# Altium schematic sheet 02_Simple_ARM.SchDoc(02_Simple_ARM)
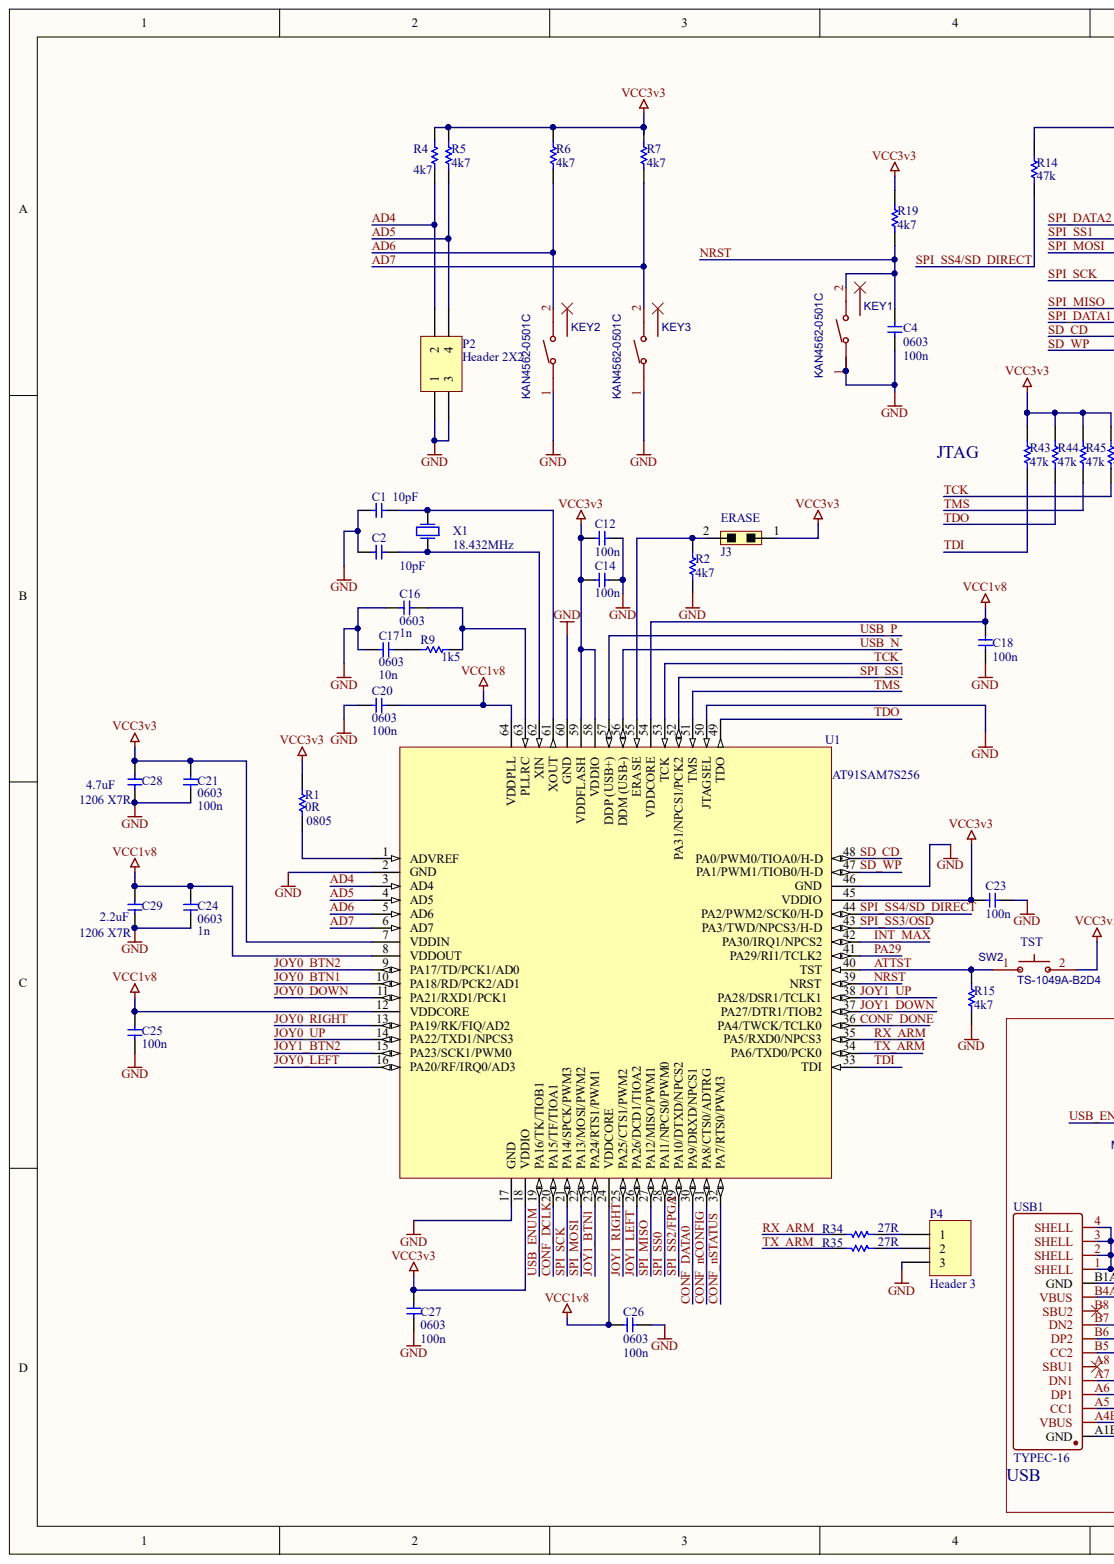
[diagram p0: 02_Simple_ARM.SchDoc, left]
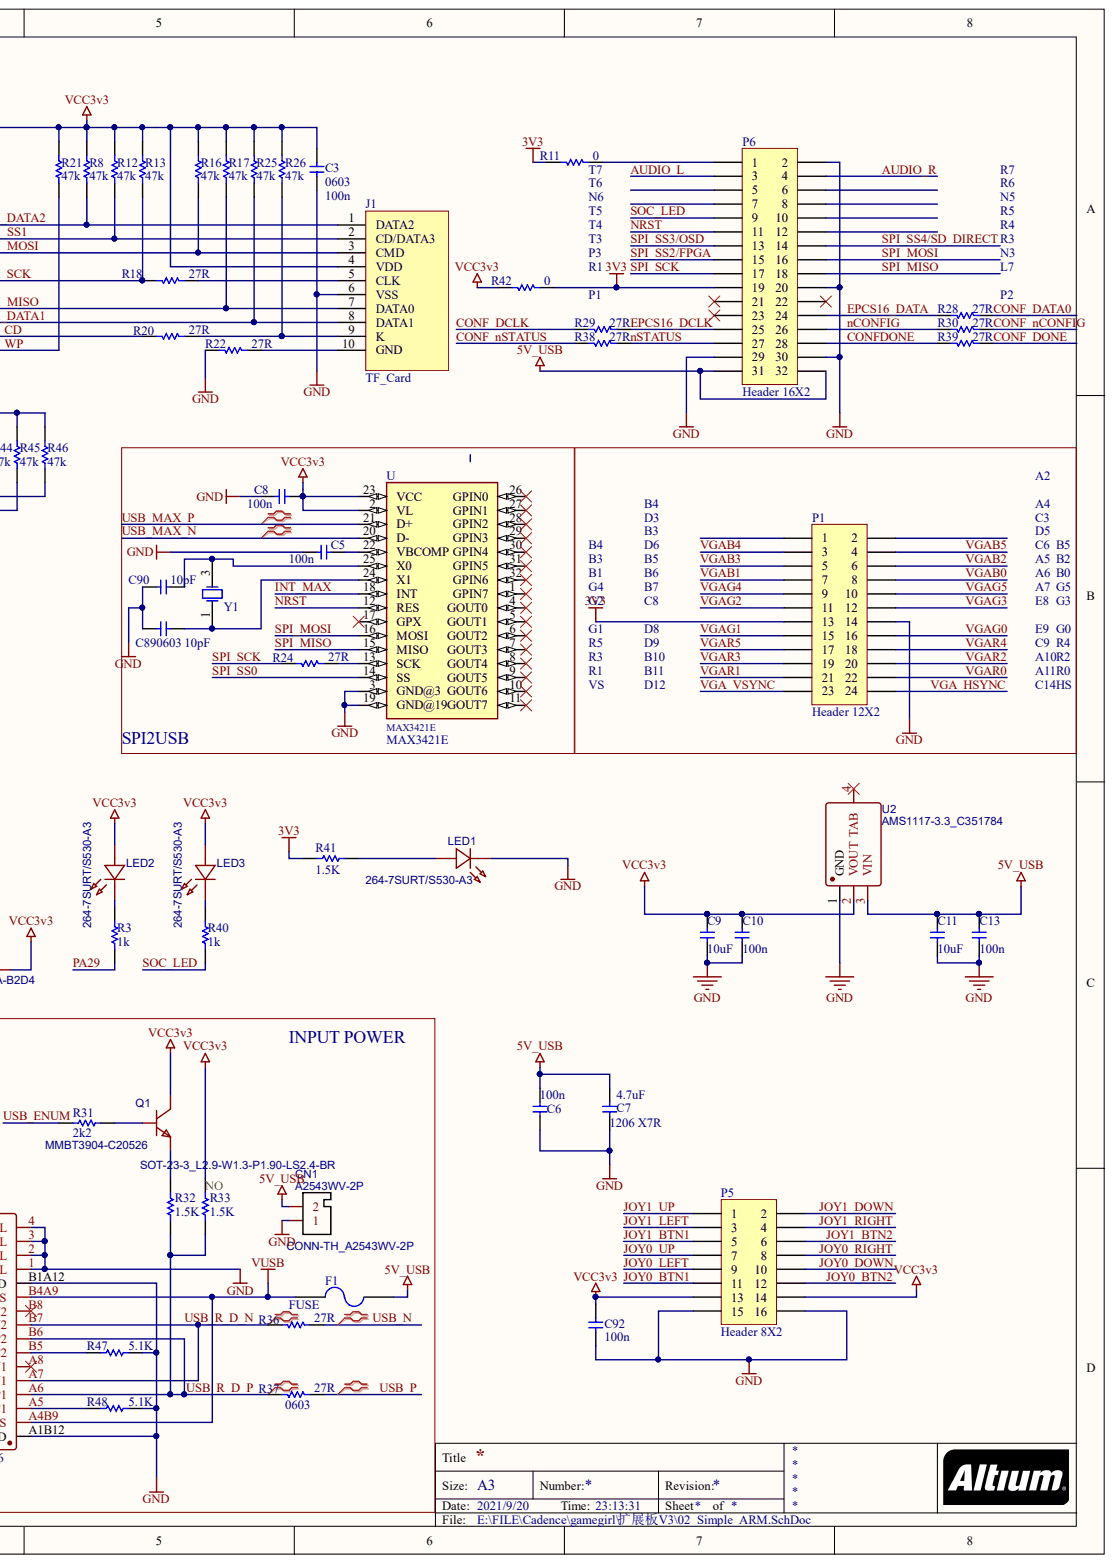
[diagram p1: 02_Simple_ARM.SchDoc, right]

## Parts
C1  value 10pF  [0603]  (hidden comment: 0603)
C2  value 10pF  [0603]  (hidden comment: 0603)
C3  0603  value 100n  [0603]
C4  0603  value 100n  [0603]
C5  value 100n  [0603]  (hidden comment: 0603)
C6  value 100n  [0603]  (hidden comment: 0603)
C7  1206 X7R  value 4.7uF  [0805]
C8  value 100n  [0603]  (hidden comment: 0603)
C9  value 10uF  [0603]  (hidden comment: 0603)
C10  value 100n  [0603]  (hidden comment: 0603)
C11  value 10uF  [0603]  (hidden comment: 0603)
C12  value 100n  [0603]  (hidden comment: 0603)
C13  value 100n  [0603]  (hidden comment: 0603)
C14  value 100n  [0603]  (hidden comment: 0603)
C16  0603  value 1n  [0603]
C17  0603  value 10n  [0603]
C18  value 100n  [0603]  (hidden comment: 0603)
C20  0603  value 100n  [0603]
C21  0603  value 100n  [0603]
C23  value 100n  [0603]  (hidden comment: 0603)
C24  0603  value 1n  [0603]
C25  value 100n  [0603]  (hidden comment: 0603)
C26  0603  value 100n  [0603]
C27  0603  value 100n  [0603]
C28  1206 X7R  value 4.7uF  [0805]
C29  1206 X7R  value 2.2uF  [0805]
C89  0603  value 10pF  [0603]
C90  value 10pF  [0603]  (hidden comment: 0603)
C92  value 100n  [0603]  (hidden comment: 0603)
CN1  A2543WV-2P  [CONN-TH_A2543WV-2P]
F1  FUSE  [FUSE_1206]
J1  TF_Card  [TF1]
J3  JUMPER2  [JUMPER2_254]  (value not drawn)
KEY1  KAN4562-0501C  [KEY-TH_KAN4562-0501C]
KEY2  KAN4562-0501C  [KEY-TH_KAN4562-0501C]
KEY3  KAN4562-0501C  [KEY-TH_KAN4562-0501C]
LED1  264-7SURT/S530-A3  [LED-TH_BD3.2-P2.54-RD]
LED2  264-7SURT/S530-A3  [LED-TH_BD3.2-P2.54-RD]
LED3  264-7SURT/S530-A3  [LED-TH_BD3.2-P2.54-RD]
P1  Header 12X2  [HDR2X12]
P2  Header 2X2  [HDR2X2]
P4  Header 3  [HDR1X3]
P5  Header 8X2  [HDR2X8]
P6  Header 16X2  [HDR2X16]
Q1  MMBT3904-C20526  [SOT-23-3_L2.9-W1.3-P1.90-LS2.4-BR]
R1  0805  value 0R  [0603]
R2  value 4k7  [0603]  (hidden comment: 0805)
R3  value 1k  [0603]  (hidden comment: 0603)
R4  value 4k7  [0603]  (hidden comment: 0805)
R5  value 4k7  [0603]  (hidden comment: 0805)
R6  value 4k7  [0603]  (hidden comment: 0805)
R7  value 4k7  [0603]  (hidden comment: 0805)
R8  value 47k  [0603]  (hidden comment: 0805)
R9  0603  value 1k5  [0603]
R11  value 0  [0603]  (hidden comment: 0603)
R12  value 47k  [0603]  (hidden comment: 0805)
R13  value 47k  [0603]  (hidden comment: 0805)
R14  value 47k  [0603]  (hidden comment: 0805)
R15  value 4k7  [0603]  (hidden comment: 0805)
R16  value 47k  [0603]  (hidden comment: 0805)
R17  value 47k  [0603]  (hidden comment: 0805)
R18  value 27R  [0603]  (hidden comment: 0603)
R19  value 4k7  [0603]  (hidden comment: 0805)
R20  value 27R  [0603]  (hidden comment: 0603)
R21  value 47k  [0603]  (hidden comment: 0805)
R22  value 27R  [0603]  (hidden comment: 0603)
R24  value 27R  [0603]  (hidden comment: 0603)
R25  value 47k  [0603]  (hidden comment: 0805)
R26  value 47k  [0603]  (hidden comment: 0805)
R28  value 27R  [0603]  (hidden comment: 0603)
R29  value 27R  [0603]  (hidden comment: 0603)
R30  value 27R  [0603]  (hidden comment: 0603)
R31  value 2k2  [0603]  (hidden comment: 0805)
R32  value 1.5K  [R0402]  (hidden comment: R0603)
R33  value 1.5K  STUFF: NO  [R0402]  (hidden comment: R0603)
R34  value 27R  [0603]  (hidden comment: 0603)
R35  value 27R  [0603]  (hidden comment: 0603)
R36  value 27R  [R0402]  (hidden comment: 0603)
R37  0603  value 27R  [R0402]
R38  value 27R  [0603]  (hidden comment: 0603)
R39  value 27R  [0603]  (hidden comment: 0603)
R40  value 1k  [0603]  (hidden comment: 0603)
R41  value 1.5K  [0603]  (hidden comment: 0805)
R42  value 0  [0603]  (hidden comment: 0603)
R43  value 47k  [R0402]  (hidden comment: 0805)
R44  value 47k  [R0402]  (hidden comment: 0805)
R45  value 47k  [R0402]  (hidden comment: 0805)
R46  value 47k  [R0402]  (hidden comment: 0805)
R47  5.1K  [R0402]
R48  5.1K  [R0402]
SW2  TS-1049A-B2D4  [SW-SMD_L3.0-W2.0-LS3.5]
U  MAX3421E  [TQFP-32_L5.0-W5.0-P0.50-LS7.0-BL]
U1  AT91SAM7S256  [LQFP64-10-05]
U2  AMS1117-3.3_C351784  [SOT-223-3_L6.5-W3.4-P2.30-LS7.0-BR]
USB1  TYPEC-16  [USB-C-16PIN]
X1  18.432MHz  [CRYSTAL_SMT]
Y1  12MHZ 20PF 10PPM  [SMD-3225_4P]  (value not drawn)

## Nets
3V3: P1.13 P6.19 R11.2 R41.1 R42.1
5V_USB: C6.2 C7.2 C11.1 C13.1 CN1.2 F1.2 P6.31 P6.32 U2.3(VIN)
GND: C1.2 C2.2 C3.1 C4.1 C5.2 C6.1 C7.1 C8.2 C9.2 C10.2 C11.2 C12.2 C13.2 C14.2 C16.1 C17.1 C18.1 C20.1 C21.1 C23.1 C24.1 C25.1 C26.2 C27.1 C28.1 C29.1 C89.2 C90.2 C92.2 CN1.1 J1.6(VSS) KEY1.1 KEY2.1 KEY3.1 LED1.1 P1.14 P2.1 P2.3 P4.3 P5.15 P5.16 P6.2 P6.20 P6.29 P6.30 R2.1 R15.2 R22.2 R47.1 R48.1 U.3(GND@3) U.19(GND@19) U1.2(GND) U1.17(GND) U1.46(GND) U1.50(JTAGSEL) U1.60(GND) U2.1(GND) USB1.1(SHELL) USB1.2(SHELL) USB1.3(SHELL) USB1.4(SHELL) USB1.A1B12(GND) USB1.B1A12(GND)
VCC1V8: C18.2 C20.2 C24.2 C25.2 C26.1 C29.2 U1.8(VDDOUT) U1.12(VDDCORE) U1.24(VDDCORE) U1.54(VDDCORE) U1.64(VDDPLL)
VCC3V3: C3.2 C8.1 C9.1 C10.1 C12.1 C14.1 C21.2 C23.2 C27.2 C28.2 C92.1 J1.4(VDD) J3.1 LED2.2 LED3.2 P5.13 P5.14 Q1.3 R1.2 R4.1 R5.1 R6.1 R7.1 R8.2 R12.2 R13.2 R14.2 R16.2 R17.2 R19.1 R21.2 R25.2 R26.2 R33.1 R42.2 R43.2 R44.2 R45.2 R46.2 SW2.2 U.2(VL) U.23(VCC) U1.7(VDDIN) U1.18(VDDIO) U1.45(VDDIO) U1.58(VDDIO) U1.59(VDDFLASH) U2.2(VOUT)
VUSB: F1.1 USB1.A4B9(VBUS) USB1.B4A9(VBUS)
AD4: P2.2 R4.2 U1.3(AD4)
AD5: P2.4 R5.2 U1.4(AD5)
AD6: KEY2.2 R6.2 U1.5(AD6)
AD7: KEY3.2 R7.2 U1.6(AD7)
ATTST: R15.1 SW2.1 U1.40(TST)
AUDIO_L: P6.3
AUDIO_R: P6.4
CONF_DATA0: R28.1 U1.30(PA9/DRXD/NPCS1)
CONF_DCLK: R29.2 U1.20(PA15/TF/TIOA1)
CONF_DONE: R39.1 U1.36(PA4/TWCK/TCLK0)
CONF_NCONFIG: R30.1 U1.31(PA8/CTS0/ADTRG)
CONF_NSTATUS: R38.2 U1.32(PA7/RTS0/PWM3)
CONFDONE: P6.28 R39.2
EPCS16_DATA: P6.24 R28.2
EPCS16_DCLK: P6.25 R29.1
INT_MAX: U.18(INT) U1.42(PA30/IRQ1/NPCS2)
JOY0_BTN1: P5.11 U1.10(PA18/RD/PCK2/AD1)
JOY0_BTN2: P5.12 U1.9(PA17/TD/PCK1/AD0)
JOY0_DOWN: P5.10 U1.11(PA21/RXD1/PCK1)
JOY0_LEFT: P5.9 U1.16(PA20/RF/IRQ0/AD3)
JOY0_RIGHT: P5.8 U1.13(PA19/RK/FIQ/AD2)
JOY0_UP: P5.7 U1.14(PA22/TXD1/NPCS3)
JOY1_BTN1: P5.5 U1.23(PA24/RTS1/PWM1)
JOY1_BTN2: P5.6 U1.15(PA23/SCK1/PWM0)
JOY1_DOWN: P5.2 U1.37(PA27/DTR1/TIOB2)
JOY1_LEFT: P5.3 U1.26(PA26/DCD1/TIOA2)
JOY1_RIGHT: P5.4 U1.25(PA25/CTS1/PWM2)
JOY1_UP: P5.1 U1.38(PA28/DSR1/TCLK1)
NCONFIG: P6.26 R30.2
NRST: C4.2 KEY1.2 P6.11 R19.2 U.12(RES) U1.39(NRST)
NSTATUS: P6.27 R38.1
PA29: R3.2 U1.41(PA29/RI1/TCLK2)
RX_ARM: R34.2 U1.35(PA5/RXD0/NPCS3)
SD_CD: R20.2 U1.48(PA0/PWM0/TIOA0/H-D)
SD_WP: R21.1 U1.47(PA1/PWM1/TIOB0/H-D)
SOC_LED: P6.9 R40.2
SPI_DATA1: J1.8(DATA1) R25.1
SPI_DATA2: J1.1(DATA2) R8.1
SPI_MISO: J1.7(DATA0) P6.18 R17.1 U.15(MISO) U1.27(PA12/MISO/PWM1)
SPI_MOSI: J1.3(CMD) P6.16 R16.1 U.16(MOSI) U1.22(PA13/MOSI/PWM2)
SPI_SCK: P6.17 R13.1 R18.2 R24.2 U1.21(PA14/SPCK/PWM3)
SPI_SS0: U.14(SS) U1.28(PA11/NPCS0/PWM0)
SPI_SS1: J1.2(CD/DATA3) R12.1 U1.52(PA31/NPCS1/PCK2)
SPI_SS2/FPGA: P6.15 U1.29(PA10/DTXD/NPCS2)
SPI_SS3/OSD: P6.13 U1.43(PA3/TWD/NPCS3/H-D)
SPI_SS4/SD_DIRECT: P6.14 R14.1 U1.44(PA2/PWM2/SCK0/H-D)
TCK: R46.1 U1.53(TCK)
TDI: R43.1 U1.33(TDI)
TDO: R44.1 U1.49(TDO)
TMS: R45.1 U1.51(TMS)
TX_ARM: R35.2 U1.34(PA6/TXD0/PCK0)
USB_ENUM: R31.1 U1.19(PA16/TK/TIOB1)
USB_MAX_N: U.20(D-)
USB_MAX_P: U.21(D+)
USB_N: R36.1 U1.56(DDM_(USB-))
USB_P: R37.1 U1.57(DDP_(USB+))
USB_R_D_N: R36.2 USB1.A7(DN1) USB1.B7(DN2)
USB_R_D_P: R32.2 R33.2 R37.2 USB1.A6(DP1) USB1.B6(DP2)
VGA_HSYNC: P1.24
VGA_VSYNC: P1.23
VGAB0: P1.8
VGAB1: P1.7
VGAB2: P1.6
VGAB3: P1.5
VGAB4: P1.3
VGAB5: P1.4
VGAG0: P1.16
VGAG1: P1.15
VGAG2: P1.11
VGAG3: P1.12
VGAG4: P1.9
VGAG5: P1.10
VGAR0: P1.22
VGAR1: P1.21
VGAR2: P1.20
VGAR3: P1.19
VGAR4: P1.18
VGAR5: P1.17
NetC1_1: C1.1 U1.61(XOUT) X1.2
NetC2_1: C2.1 U1.62(XIN) X1.1
NetC5_1: C5.1 U.22(VBCOMP)
NetC16_2: C16.2 R9.2 U1.63(PLLRC)
NetC17_2: C17.2 R9.1
NetC89_1: C89.1 U.24(X1) Y1.1
NetC90_1: C90.1 U.25(X0) Y1.3
NetJ1_5: J1.5(CLK) R18.1
NetJ1_9: J1.9(K) R20.1 R26.1
NetJ1_10: J1.10(GND) R22.1
NetJ3_2: J3.2 R2.2 U1.55(ERASE)
NetLED1_2: LED1.2 R41.2
NetLED2_1: LED2.1 R3.1
NetLED3_1: LED3.1 R40.1
NetP4_1: P4.1 R34.1
NetP4_2: P4.2 R35.1
NetP6_1: P6.1 R11.1
NetQ1_1: Q1.1 R31.2
NetQ1_2: Q1.2 R32.1
NetR1_1: R1.1 U1.1(ADVREF)
NetR24_1: R24.1 U.13(SCK)
NetR47_2: R47.2 USB1.B5(CC2)
NetR48_2: R48.2 USB1.A5(CC1)
N00032:

Not connected: KEY1 0; KEY2 0; KEY3 0; P1 1 2; P6 5 6 7 8 10 12 21 22 23; U 1(GPIN7) 4(GOUT0) 5(GOUT1) 6(GOUT2) 7(GOUT3) 8(GOUT4) 9(GOUT5) 10(GOUT6) 11(GOUT7) 17(GPX) 26(GPIN0) 27(GPIN1) 28(GPIN2) 29(GPIN3) 30(GPIN4) 31(GPIN5) 32(GPIN6); U2 4(TAB); USB1 A8(SBU1) B8(SBU2)

## Library data of the parts
C1 C2 C89 C90 = CAP_0805: 0805 footprint non-polarised capacitor; Component Kind: Standard; Library Name: Integrated Comp_lib.IntLib
C3-C6 C8-C14 C18 C21 C23-C27 C92 = CAP_0603: 0603 footprint non-polorised capacitor; Component Kind: Standard; Library Name: Integrated Comp_lib.IntLib
C7 C28 C29 = CAP_1206: 1206 footprint non-polorised capacitor; Component Kind: Standard; Library Name: Integrated Comp_lib.IntLib
C16 = CAP_0603: 0603 footprint non-polorised capacitor; Value: 1n; Component Kind: Standard; Library Name: Integrated Comp_lib.IntLib
C17 = CAP_0603: 0603 footprint non-polorised capacitor; Value: 10n; Component Kind: Standard; Library Name: Integrated Comp_lib.IntLib
C20 = CAP_0603: 0603 footprint non-polorised capacitor; Value: 100n; Component Kind: Standard; Library Name: Integrated Comp_lib.IntLib
CN1 = A2543WV-2P: BOM_Manufacturer: Changjiang Connectors; Contributor: LCSC; Package Display: Yes; BOM_Manufacturer Part: A2543WV-2P; BOM_Supplier: LCSC; BOM_Supplier Part: C239362; Component Kind: Standard; link: https://item.szlcsc.com/238466.html?ref=editor&logined=true; spicePre: C; spiceSymbolName: A2543WV-2P
J1 = TF_Card: TF卡座; 版权归属: 源创客; BOM名称: TF卡座; Component Kind: Standard; Library Name: 4.1   - 接口类.SchLib; 学习资源: www.ooocke.com
J3 = JUMPER2: 2 Pin Jumper, 2.54mm; Component Kind: Standard; Library Name: ARM_projects.IntLib
KEY1-KEY3 = KAN4562-0501C: BOM_Manufacturer: GANGYUAN; Contributor: LCSC; SMT Type: Extend; BOM_JLCPCB SMD: Yes; BOM_Manufacturer Part: KAN4562-0501C; BOM_Supplier: LCSC; BOM_Supplier Part: C231728; Component Kind: Standard; spicePre: K; spiceSymbolName: KAN4562-0501C
LED1-LED3 = 264-7SURT/S530-A3: BOM_Manufacturer: Everlight Elec; Contributor: LCSC; BOM_Manufacturer Part: 264-7SURT/S530-A3; BOM_Supplier: LCSC; BOM_Supplier Part: C710207; Component Kind: Standard; spicePre: L; spiceSymbolName: 264-7SURT/S530-A3
P1 = Header 12X2: Header, 12-Pin, Dual row; Component Kind: Standard; LatestRevisionDate: 17-Jul-2002; LatestRevisionNote: Re-released for DXP Platform.; Library Name: Miscellaneous Connectors.IntLib; Signal Integrity: Connector; Publisher: Altium Limited
P2 = Header 2X2: Header, 2-Pin, Dual row; Component Kind: Standard; LatestRevisionDate: 17-Jul-2002; LatestRevisionNote: Re-released for DXP Platform.; Library Name: Miscellaneous Connectors.IntLib; Signal Integrity: Connector; Publisher: Altium Limited
P4 = Header 3: Header, 3-Pin; Component Kind: Standard; LatestRevisionDate: 17-Jul-2002; LatestRevisionNote: Re-released for DXP Platform.; Library Name: Miscellaneous Connectors.IntLib; Signal Integrity: Connector; Publisher: Altium Limited
P5 = Header 8X2: Header, 8-Pin, Dual row; Component Kind: Standard; LatestRevisionDate: 17-Jul-2002; LatestRevisionNote: Re-released for DXP Platform.; Library Name: Miscellaneous Connectors.IntLib; Signal Integrity: Connector; Publisher: Altium Limited
P6 = Header 16X2: Header, 16-Pin, Dual row; Component Kind: Standard; LatestRevisionDate: 17-Jul-2002; LatestRevisionNote: Re-released for DXP Platform.; Library Name: Miscellaneous Connectors.IntLib; Signal Integrity: Connector; Publisher: Altium Limited
Q1 = MMBT3904-C20526: BOM_Manufacturer: CJ; Contributor: 立创EDA官方封装库; Package Display: Yes; SMT Type: Basic; BOM_JLCPCB SMD: Yes; BOM_Manufacturer Part: MMBT3904; BOM_Supplier: LCSC; BOM_Supplier Part: C20526; Component Kind: Standard; spicePre: Q; spiceSymbolName: MMBT3904-C20526
R1-R9 R12-R17 R19 R21 R25 R26 R31 R40 R41 R43-R46 = RES_0805: 0805 footprint resistor; Component Kind: Standard; Library Name: Integrated Comp_lib.IntLib
R11 R18 R20 R22 R24 R28-R30 R34-R39 R42 = RES_0603: 0603 footprint resistor; Component Kind: Standard; Library Name: Integrated Comp_lib.IntLib
R32 R33 = R0603: Resistor; Component Kind: Standard; LatestRevisionDate: 17-Jul-2002; LatestRevisionNote: Re-released for DXP Platform.; Library Name: MYLIB.IntLib; PackageDescription: Axial Device, Thru-Hole; 2 Leads; 0.3 in Pin Spacing; PackageReference: AXIAL-0.3; Published: 8-Jun-2000; Signal Integrity: Res; Simulation: RESISTOR; Publisher: Altium Limited
R47 R48 = RES: Component Kind: Standard; Library Name: 电阻.IntLib
SW2 = TS-1049A-B2D4: BOM_Manufacturer: Yuandi; Contributor: LCSC; BOM_Manufacturer Part: TS-1049A-B2D4; BOM_Supplier: LCSC; BOM_Supplier Part: C585167; Component Kind: Standard; spicePre: S; spiceSymbolName: TS-1049A-B2D4
U = MAX3421E: USB Controller USB 2.0 SPI Interface 32-TQFN-EP _5x5_; MF: Maxim Integrated; Availability: Unavailable; Component Kind: Standard; Library Name: MAX3421E.IntLib; MP: MAX3421E; Package: TQFP-32 Maxim Integrated; Price: None; SnapEDA_Link: https://www.snapeda.com/parts/MAX3421E/Maxim%20Integrated/view-part/1077099/?ref=snap
U1 = AT91SAM7S256: Atmel ARM7 microcontroller, 256k Flash; Component Kind: Standard; Library Name: ARM_projects.IntLib; Value: AT91SAM7S256
U2 = AMS1117-3.3_C351784: BOM_Manufacturer: PUOLOP; Contributor: LCSC; BOM_Manufacturer Part: AMS1117-3.3; BOM_Supplier: LCSC; BOM_Supplier Part: C351784; Component Kind: Standard; spicePre: U; spiceSymbolName: AMS1117-3.3_C351784
USB1 = USB-C-16PIN: Component Kind: Standard; Library Name: USB.IntLib
X1 = CRYSTAL_SMT: SMT crystal; Component Kind: Standard; Library Name: ARM_projects.IntLib; Value: 18.432MHz
Y1 = SMD-3225_4P  12MHZ 20PF 10PPM: 贴片晶振; Component Kind: Standard; ComponentLink1Description: 供应商链接; ComponentLink1URL: http://www.szlcsc.com/product/details_9522.html; Library Name: CrystalOscillator.SchLib; Package: SMD-3225_4P; Supplier: LC; SuppliersPartNumber: C9002
F1 = FUSE: Component Kind: Standard; Library Name: 保险丝.IntLib
